# Altium schematic sheet LB11920.SchDoc
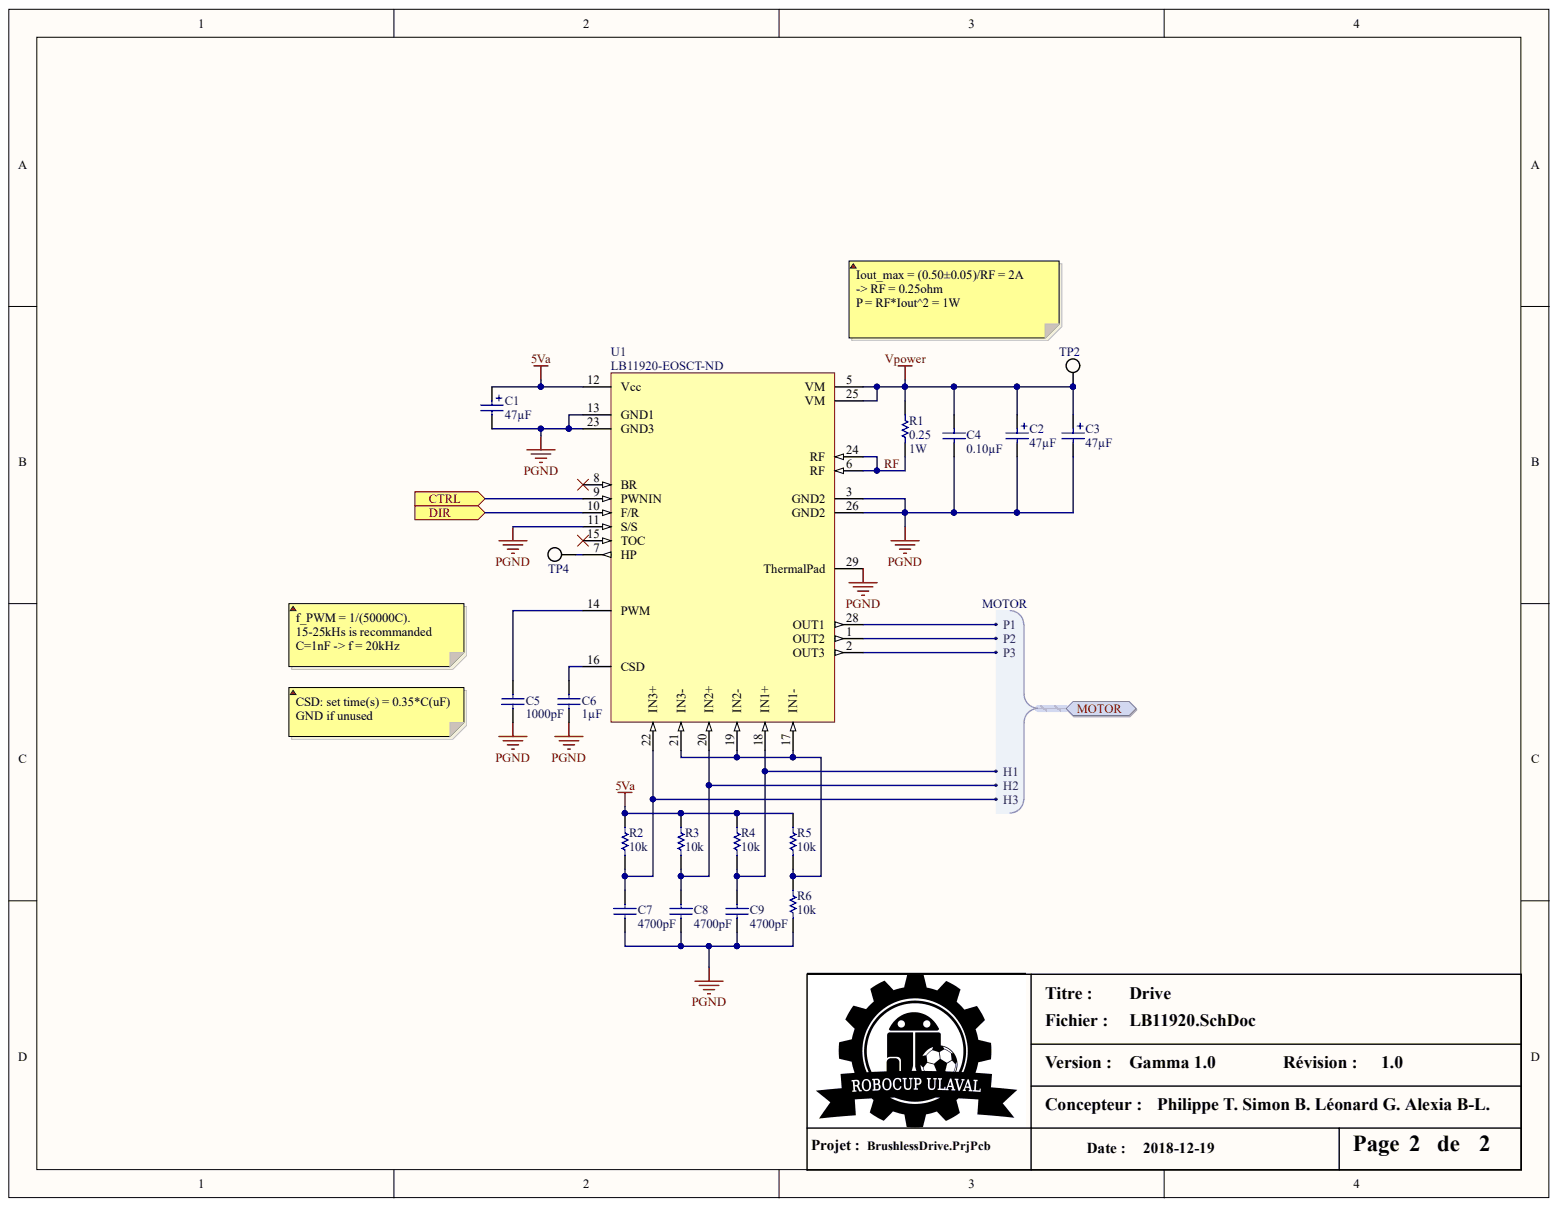
[diagram p0: LB11920.SchDoc]

## Parts
C1  Capacitance: 47µF  [CAP 6.6x6.6mm]  (hidden comment: 865080645012)
C2  Capacitance: 47µF  [CAP 6.6x6.6mm]  (hidden comment: 865080645012)
C3  Capacitance: 47µF  [CAP 6.6x6.6mm]  (hidden comment: 865080645012)
C4  Capacitance: 0.10µF  [0603]  (hidden comment: CL10B104KB8SFNC)
C5  Capacitance: 1000pF  [0603]  (hidden comment: CL10B102KB8NNNC)
C6  Capacitance: 1µF  [0805]  (hidden comment: CL21B105KBFNFNE)
C7  Capacitance: 4700pF  [0603]  (hidden comment: CL10B472KB8NNNC)
C8  Capacitance: 4700pF  [0603]  (hidden comment: CL10B472KB8NNNC)
C9  Capacitance: 4700pF  [0603]  (hidden comment: CL10B472KB8NNNC)
R1  Power (Watts): 1W  Resistance (Ohms): 0.25  [2010]  (hidden comment: CSRN2010FKR250)
R2  Resistance (Ohms): 10k  [0603]  (hidden comment: RC0603JR-0710KL)
R3  Resistance (Ohms): 10k  [0603]  (hidden comment: RC0603JR-0710KL)
R4  Resistance (Ohms): 10k  [0603]  (hidden comment: RC0603JR-0710KL)
R5  Resistance (Ohms): 10k  [0603]  (hidden comment: RC0603JR-0710KL)
R6  Resistance (Ohms): 10k  [0603]  (hidden comment: RC0603JR-0710KL)
TP2  testpoint  [0805 testpoint]  (value not drawn)
TP4  testpoint  [0805 testpoint]  (value not drawn)
U1  LB11920-E  Supplier Part Number 1: LB11920-EOSCT-ND  [DIP28]

## Ports
CTRL, DIR, MOTOR, MOTOR.H1, MOTOR.H2, MOTOR.H3, MOTOR.P1, MOTOR.P2, MOTOR.P3

## Nets
5VA: C1.1 R2.2 R3.2 R4.2 R5.2 U1.12(Vcc)
PGND: C1.2 C2.2 C3.2 C4.1 C5.1 C6.1 C7.1 C8.1 C9.1 R6.1 U1.3(GND2) U1.11(S/S) U1.13(GND1) U1.23(GND3) U1.26(GND2) U1.29(ThermalPad)
VPOWER: C2.1 C3.1 C4.2 R1.2 TP2.1 U1.5(VM) U1.25(VM)
CTRL: U1.9(PWNIN) ; port CTRL
DIR: U1.10(F/R) ; port DIR
RF: R1.1 U1.6(RF) U1.24(RF)
NetC5_2: C5.2 U1.14(PWM)
NetC6_2: C6.2 U1.16(CSD)
NetC7_2: C7.2 R2.1 U1.22(IN3+) ; port MOTOR
NetC8_2: C8.2 R3.1 U1.20(IN2+) ; port MOTOR
NetC9_2: C9.2 R4.1 U1.18(IN1+) ; port MOTOR
NetR5_1: R5.1 R6.2 U1.17(IN1-) U1.19(IN2-) U1.21(IN3-)
NetTP4_1: TP4.1 U1.7(HP)
NetU1_1: U1.1(OUT2) ; port MOTOR
NetU1_2: U1.2(OUT3) ; port MOTOR
NetU1_28: U1.28(OUT1) ; port MOTOR

Not connected: U1 8(BR) 15(TOC)

## Library data of the parts
C1-C3 = 865080645012: CAP 47 UF 20% 50 V; Manufacturer: Wurth Electronics Inc; Impedance: 700 mOhm; Lifetime @ Temp.: 2000 Hrs @ 105°C; Polarization: Polar; Ripple Current: 230mA @ 100kHz; Surface Mount Land Size: 0.260" L x 0.260" W (6.60mm x 6.60mm); Voltage Rating: 50V; Applications: General Purpose; Category: Capacitors; Component Kind: Standard; ComponentLink1Description: http://katalog.we-online.de/pbs/datasheet/865080645012.pdf; ComponentLink1URL: http://katalog.we-online.de/pbs/datasheet/865080645012.pdf; ESR (Equivalent Series Resistance): -; Height - Seated (Max): 0.303" (7.70mm); Lead Spacing: -; Library Name: ResCap.SchLib; Manufacturer Part Number: 865080645012; Mounting Type: Surface Mount; Operating Temperature: -55°C ~ 105°C; Package / Case: Radial, Can - SMD; Packaging: Cut Tape (CT); Pricing 1: 1=0.48, 50=0.4072, 100=0.3547, 250=0.31528 (CAD); RoHS: RoHS Compliant; Size / Dimension: 0.248" Dia (6.30mm); Stock 1: 803; Supplier 1: Digi-Key; Supplier Part Number 1: 732-8457-1-ND; Tolerance: ±20%
C4 = CL10B104KB8SFNC: CAP CER 0.1UF 50V X7R 0603; Manufacturer: Samsung Electro-Mechanics America, Inc.; Tolerance: ±10%; Applications: Boardflex Sensitive; Category: Capacitors; Component Kind: Standard; ComponentLink1Description: http://www.samsungsem.com/kr/support/product-search/mlcc/CL10B104KB8SFNC.jsp; ComponentLink1URL: http://www.samsungsem.com/kr/support/product-search/mlcc/CL10B104KB8SFNC.jsp; Features: Soft Termination; Height - Seated (Max): -; Lead Spacing: -; Lead Style: -; Library Name: ResCap.SchLib; Manufacturer Part Number: CL10B104KB8SFNC; Mounting Type: Surface Mount, MLCC; Operating Temperature: -55°C ~ 125°C; Package / Case: 0603 (1608 Metric); Packaging: Cut Tape (CT); Pricing 1: 1=0.14, 10=0.028, 25=0.0204, 50=0.0156, 100=0.0127, 250=0.01064, 500=0.00908, 1000=0.00712 (CAD); Ratings: -; RoHS: RoHS Compliant; Size / Dimension: 0.063" L x 0.031" W (1.60mm x 0.80mm); Stock 1: 2612665; Supplier 1: Digi-Key; Supplier Part Number 1: 1276-1936-1-ND; Temperature Coefficient: X7R; Thickness (Max): 0.035" (0.90mm); Voltage - Rated: 50V
C5 = CL10B102KB8NNNC: CAP CER 1000PF 50V X7R 0603; Manufacturer: Samsung Electro-Mechanics America, Inc.; Tolerance: ±10%; Applications: General Purpose; Category: Capacitors; Component Kind: Standard; ComponentLink1Description: http://www.samsungsem.com/kr/support/product-search/mlcc/CL10B102KB8NNNC.jsp; ComponentLink1URL: http://www.samsungsem.com/kr/support/product-search/mlcc/CL10B102KB8NNNC.jsp; Features: -; Height - Seated (Max): -; Lead Spacing: -; Lead Style: -; Library Name: ResCap.SchLib; Manufacturer Part Number: CL10B102KB8NNNC; Mounting Type: Surface Mount, MLCC; Operating Temperature: -55°C ~ 125°C; Package / Case: 0603 (1608 Metric); Packaging: Cut Tape (CT); Pricing 1: 1=0.14, 10=0.024, 25=0.0172, 50=0.013, 100=0.0109, 500=0.00768, 1000=0.00597 (CAD); Ratings: -; RoHS: RoHS Compliant; Size / Dimension: 0.063" L x 0.031" W (1.60mm x 0.80mm); Stock 1: 295372; Supplier 1: Digi-Key; Supplier Part Number 1: 1276-1018-1-ND; Temperature Coefficient: X7R; Thickness (Max): 0.035" (0.90mm); Voltage - Rated: 50V
C6 = CL21B105KBFNFNE: CAP CER 1UF 50V X7R 0805; Manufacturer: Samsung Electro-Mechanics America, Inc.; Tolerance: ±10%; Applications: General Purpose; Category: Capacitors; Component Kind: Standard; ComponentLink1Description: http://www.samsungsem.com/kr/support/product-search/mlcc/CL21B105KBFNFNE.jsp; ComponentLink1URL: http://www.samsungsem.com/kr/support/product-search/mlcc/CL21B105KBFNFNE.jsp; Features: -; Height - Seated (Max): -; Lead Spacing: -; Lead Style: -; Library Name: ResCap.SchLib; Manufacturer Part Number: CL21B105KBFNFNE; Mounting Type: Surface Mount, MLCC; Operating Temperature: -55°C ~ 125°C; Package / Case: 0805 (2012 Metric); Packaging: Cut Tape (CT); Pricing 1: 1=0.27, 10=0.186, 25=0.14, 50=0.1072, 100=0.0884, 500=0.06238, 1000=0.05123 (CAD); Ratings: -; RoHS: RoHS Compliant; Size / Dimension: 0.079" L x 0.049" W (2.00mm x 1.25mm); Stock 1: 21699; Supplier 1: Digi-Key; Supplier Part Number 1: 1276-2928-1-ND; Temperature Coefficient: X7R; Thickness (Max): 0.053" (1.35mm); Voltage - Rated: 50V
C7-C9 = CL10B472KB8NNNC: CAP CER 4700PF 50V X7R 0603; Manufacturer: Samsung Electro-Mechanics America, Inc.; Tolerance: ±10%; Applications: General Purpose; Category: Capacitors; Component Kind: Standard; ComponentLink1Description: http://www.samsungsem.com/kr/support/product-search/mlcc/CL10B472KB8NNNC.jsp; ComponentLink1URL: http://www.samsungsem.com/kr/support/product-search/mlcc/CL10B472KB8NNNC.jsp; Features: -; Height - Seated (Max): -; Lead Spacing: -; Lead Style: -; Library Name: ResCap.SchLib; Manufacturer Part Number: CL10B472KB8NNNC; Mounting Type: Surface Mount, MLCC; Operating Temperature: -55°C ~ 125°C; Package / Case: 0603 (1608 Metric); Packaging: Cut Tape (CT); Pricing 1: 1=0.14, 10=0.034, 25=0.0244, 50=0.0188, 100=0.0159, 500=0.01118, 1000=0.0087 (CAD); Ratings: -; RoHS: RoHS Compliant; Size / Dimension: 0.063" L x 0.031" W (1.60mm x 0.80mm); Stock 1: 159154; Supplier 1: Digi-Key; Supplier Part Number 1: 1276-1098-1-ND; Temperature Coefficient: X7R; Thickness (Max): 0.035" (0.90mm); Voltage - Rated: 50V
R1 = CSRN2010FKR250: RES SMD 0.25 OHM 1% 1W 2010; Manufacturer: Stackpole Electronics Inc.; Temperature Coefficient: ±250ppm/°C; Category: Resistors; Component Kind: Standard; ComponentLink1Description: http://www.seielect.com/catalog/SEI-CSR_CSRN.pdf; ComponentLink1URL: http://www.seielect.com/catalog/SEI-CSR_CSRN.pdf; Composition: Thick Film; Failure Rate: -; Features: Current Sense; Height: 0.030" (0.75mm); Library Name: ResCap.SchLib; Manufacturer Part Number: CSRN2010FKR250; Number of Terminations: 2; Operating Temperature: -55°C ~ 155°C; Package / Case: 2010 (5025 Metric); Packaging: Cut Tape (CT); Part Status: Active; Pricing 1: 1=0.93, 10=0.662, 50=0.437, 100=0.3443, 250=0.29132, 500=0.23834 (CAD); RoHS: RoHS Compliant; Size / Dimension: 0.197" L x 0.097" W (5.00mm x 2.45mm); Stock 1: 35460; Supplier 1: Digi-Key; Supplier Device Package: 2010; Supplier Part Number 1: CSRN2010FKR250CT-ND; Tolerance: ±1%
R2-R6 = RC0603JR-0710KL: RES SMD 10K OHM 5% 1/10W 0603; Manufacturer: Yageo; Power (Watts): 0.1W, 1/10W; Temperature Coefficient: ±100ppm/°C; Category: Resistors; Component Kind: Standard; ComponentLink1Description: http://www.yageo.com/documents/recent/PYu-RC_Group_51_RoHS_L_04.pdf; ComponentLink1URL: http://www.yageo.com/documents/recent/PYu-RC_Group_51_RoHS_L_04.pdf; Composition: Thick Film; Features: Moisture Resistant; Height: 0.022" (0.55mm); Library Name: ResCap.SchLib; Manufacturer Part Number: RC0603JR-0710KL; Number of Terminations: 2; Operating Temperature: -55°C ~ 155°C; Package / Case: 0603 (1608 Metric); Packaging: Cut Tape (CT); Pricing 1: 1=0.15, 10=0.017, 25=0.012, 100=0.0067, 250=0.00508, 500=0.00408, 1000=0.003, 2500=0.0026 (CAD); RoHS: RoHS Compliant; Size / Dimension: 0.063" L x 0.031" W (1.60mm x 0.80mm); Stock 1: 5547866; Supplier 1: Digi-Key; Supplier Device Package: 0603; Supplier Part Number 1: 311-10KGRCT-ND; Tolerance: ±5%
U1 = LB11920-E: IC MOTOR DRIVER PAR 28HDIP; Manufacturer: ON Semiconductor; Current - Output: 3.5A; Function: Driver - Fully Integrated, Control and Power Stage; Interface: Parallel; Motor Type - AC, DC: Brushless DC (BLDC); Output Configuration: Half Bridge (3); Voltage - Load: 9.5 V ~ 30 V; Voltage - Supply: 4.5 V ~ 5.5 V; Applications: General Purpose; Category: Integrated Circuits (ICs); Component Kind: Standard; ComponentLink1Description: http://www.onsemi.com/pub/Collateral/EN7229-D.PDF; ComponentLink1URL: http://www.onsemi.com/pub/Collateral/EN7229-D.PDF; Library Name: BrushlessDrive.SchLib; Manufacturer Part Number: LB11920-E; Motor Type - Stepper: -; Mounting Type: Through Hole; Operating Temperature: -20°C ~ 80°C (TA); Package / Case: 28-SDIP (0.500", 12.70mm) Exposed Pad; Packaging: Cut Tape (CT); Pricing 1: 1=10.11, 10=9.154 (CAD); RoHS: RoHS Compliant; Step Resolution: -; Stock 1: 65; Supplier 1: Digi-Key; Supplier Device Package: 28-DIP; Technology: Bipolar
TP2 TP4 = testpoint: Component Kind: Standard; Description: Testpoint; Library Name: BrushlessDrive.SchLib
